# Altium schematic sheet 1.WIZ750JR_W7500.SchDoc
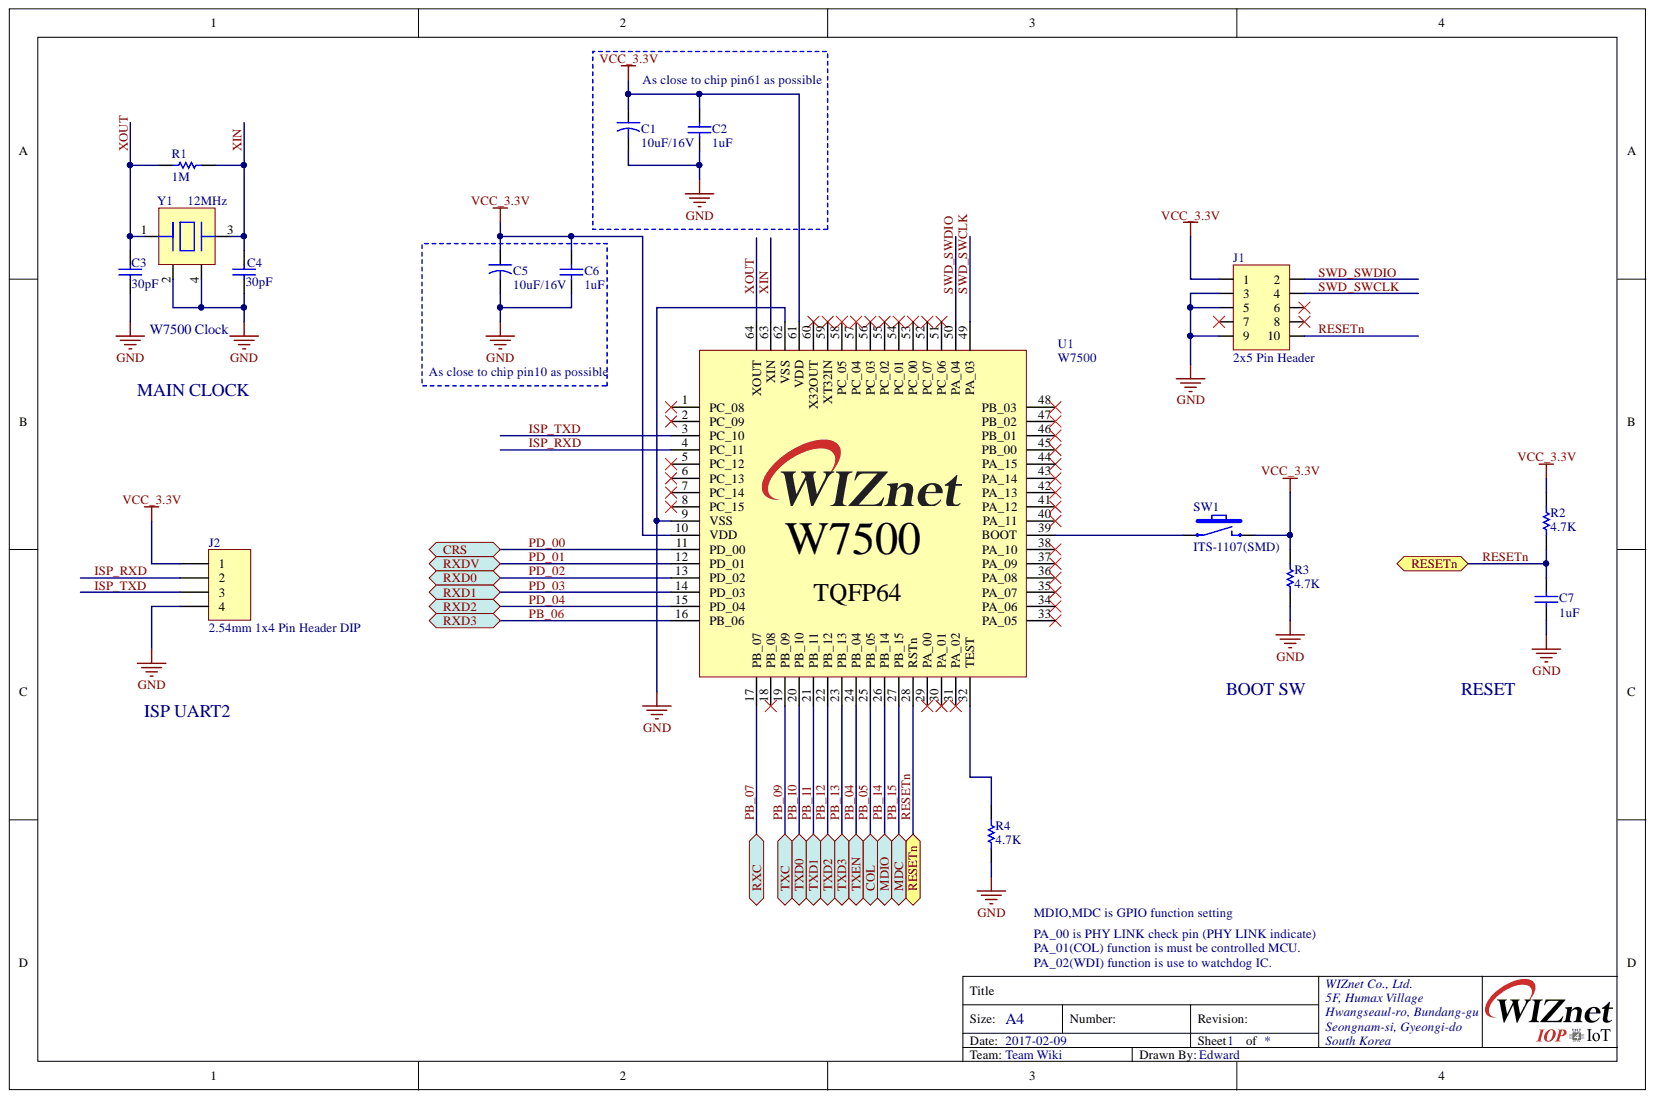
[diagram p0: 1.WIZ750JR_W7500.SchDoc]

## Parts
C1  10uF/16V  [CAP-A Size]
C2  1uF  [CAP-0402]
C3  30pF  [CAP-0402]
C4  30pF  [CAP-0402]
C5  10uF/16V  [CAP-A Size]
C6  1uF  [CAP-0402]
C7  1uF  [CAP-0402]
J1  2x5 Pin Header  [IDC(SMD)-2X5(1.27MM)]
J2  2.54mm 1x4 Pin Header DIP  [IDC-1X4]
R1  1M  [RES-0402]
R2  4.7K  [RES-0402]
R3  4.7K  [RES-0402]
R4  4.7K  [RES-0402]
SW1  ITS-1107(SMD)  [ITS-1107(SMD)]
U1  W7500  [W7500-LQFP64]
Y1  12MHz  [OSC-TZ1067B]

## Ports
COL, CRS, MDC, MDIO, RESETN, RXC, RXD0, RXD1, RXD2, RXD3, RXDV, TXC, TXD0, TXD1, TXD2, TXD3, TXEN

## Nets
GND: C1.2 C2.1 C3.1 C4.1 C5.2 C6.1 C7.1 J1.3 J1.5 J1.9 J2.4 R3.2 R4.1 U1.9(VSS) U1.62(VSS) Y1.2 Y1.4
VCC_3.3V: C1.1 C2.2 C5.1 C6.2 J1.1 J2.1 R2.1 R3.1 SW1.2 U1.10(VDD) U1.61(VDD)
ISP_RXD: J2.2 U1.4(PC_11)
ISP_TXD: J2.3 U1.3(PC_10)
PB_04: U1.24(PB_04) ; port TXEN
PB_05: U1.25(PB_05) ; port COL
PB_06: U1.16(PB_06) ; port RXD3
PB_07: U1.17(PB_07) ; port RXC
PB_09: U1.19(PB_09) ; port TXC
PB_10: U1.20(PB_10) ; port TXD0
PB_11: U1.21(PB_11) ; port TXD1
PB_12: U1.22(PB_12) ; port TXD2
PB_13: U1.23(PB_13) ; port TXD3
PB_14: U1.26(PB_14) ; port MDIO
PB_15: U1.27(PB_15) ; port MDC
PD_00: U1.11(PD_00) ; port CRS
PD_01: U1.12(PD_01) ; port RXDV
PD_02: U1.13(PD_02) ; port RXD0
PD_03: U1.14(PD_03) ; port RXD1
PD_04: U1.15(PD_04) ; port RXD2
RESETN: C7.2 J1.10 R2.2 U1.28(RSTn) ; port RESETN
SWD_SWCLK: J1.4 U1.49(PA_03)
SWD_SWDIO: J1.2 U1.50(PA_04)
XIN: C4.2 R1.2 U1.63(XIN) Y1.3
XOUT: C3.2 R1.1 U1.64(XOUT) Y1.1
NetR4_2: R4.2 U1.32(TEST)
NetSW1_1: SW1.1 U1.39(BOOT)

Not connected: J1 6 7 8; U1 1(PC_08) 2(PC_09) 5(PC_12) 6(PC_13) 7(PC_14) 8(PC_15) 18(PB_08) 29(PA_00) 30(PA_01) 31(PA_02) 33(PA_05) 34(PA_06) 35(PA_07) 36(PA_08) 37(PA_09) 38(PA_10) 40(PA_11) 41(PA_12) 42(PA_13) 43(PA_14) 44(PA_15) 45(PB_00) 46(PB_01) 47(PB_02) 48(PB_03) 51(PC_06) 52(PC_07) 53(PC_00) 54(PC_01) 55(PC_02) 56(PC_03) 57(PC_04) 58(PC_05) 59(XT32IN) 60(X32OUT)

## Library data of the parts
C1 C5 = CAP-A Size: Tantla CAP A Size EIA/IECQ 3216; Component Kind: Standard; Library Name: Scott_lib.IntLib
C2-C4 C6 C7 = CAP-0402: Ceramic CAP 0402(1005); Component Kind: Standard; Library Name: Scott_lib.IntLib
J1 = 1.27mm 2x5 Pin Header SMD: Component Kind: Standard; Description: 1.27mm 2x5 Pin Header SMD; Library Name: Scott_lib.IntLib
J2 = Header 1x4: pin header 2.54mm 1x4; Component Kind: Standard; LatestRevisionDate: 17-Jul-2002; LatestRevisionNote: Re-released for DXP Platform.; Signal Integrity: Connector; Library Name: Edward.IntLib; Publisher: Altium Limited
R1-R4 = RES-0402: Component Kind: Standard; Description: RES-0402; Library Name: Scott_lib.IntLib
SW1 = ITS-1107(SMD): TACT SW, SMD; Component Kind: Standard; Library Name: Edward.IntLib
U1 = W7500: WIZnet ARM Cortex-M0; Component Kind: Standard; Library Name: Scott_lib.IntLib
Y1 = OSC-TZ1067B-12MHz: X-tal 12MHz,3.2x2.5 SMD, CL=20pF; Component Kind: Standard; Library Name: Scott_lib.IntLib
